# Altium schematic sheet Sheet2.SchDoc
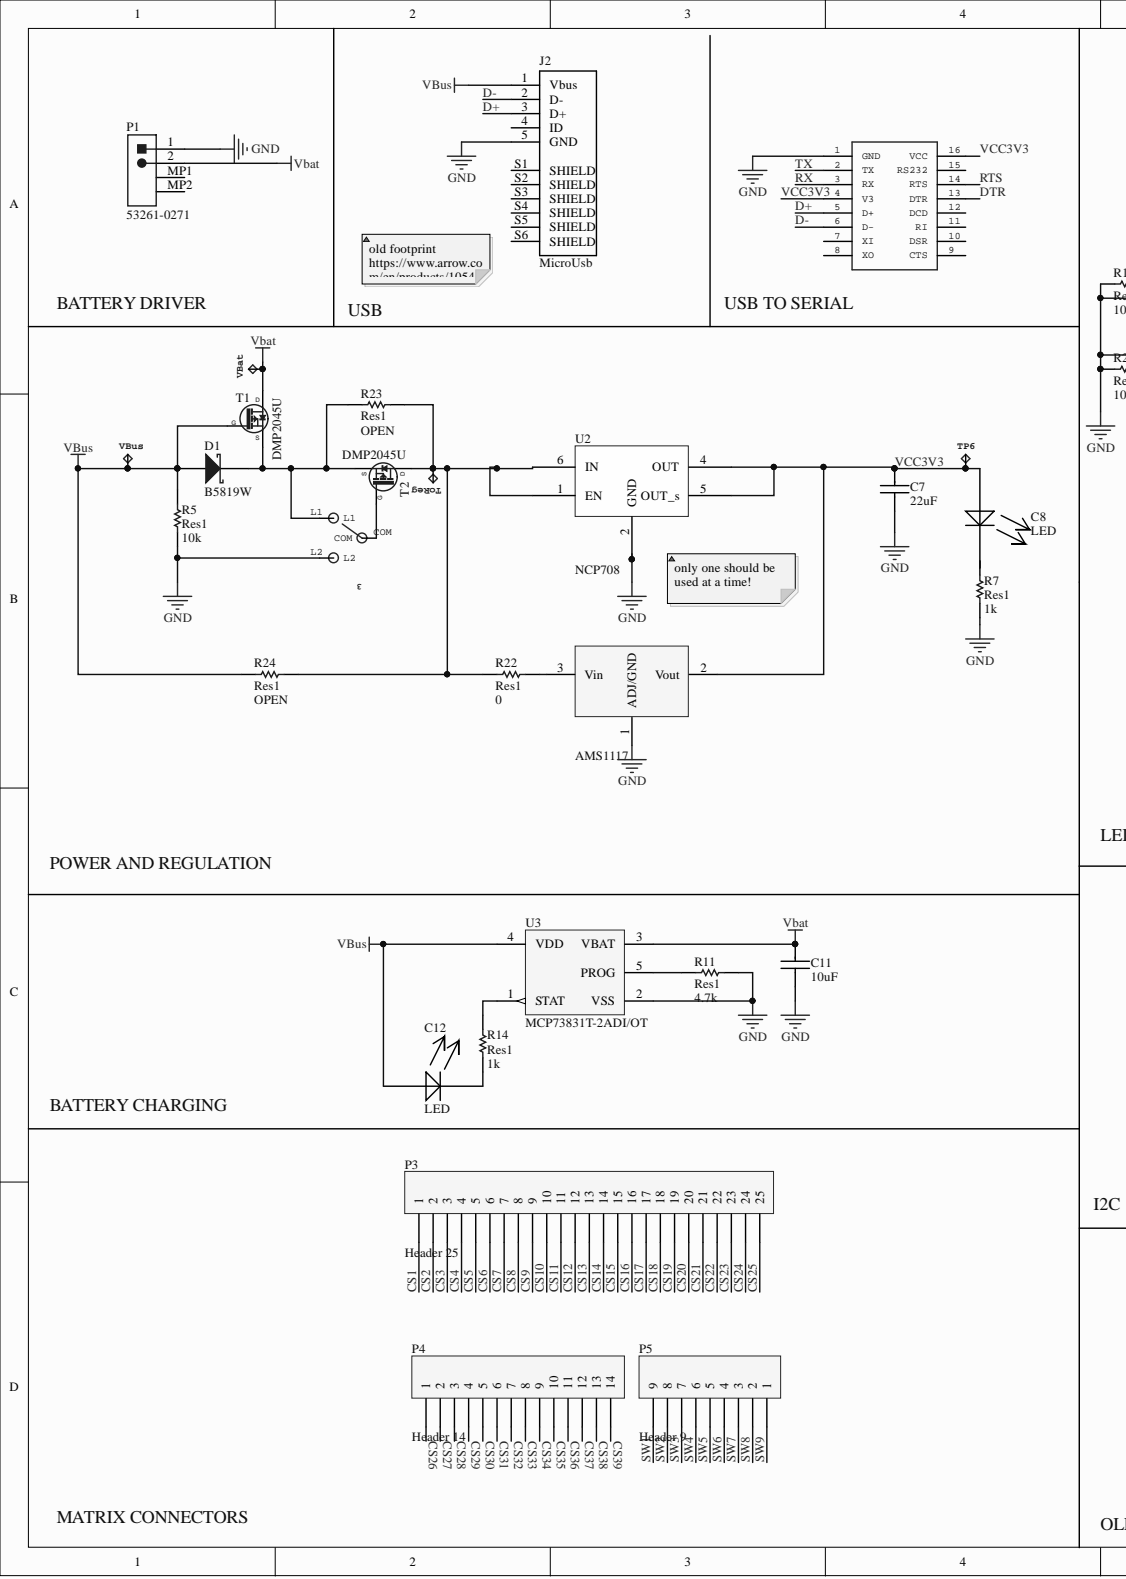
[diagram p0: Sheet2.SchDoc, left]
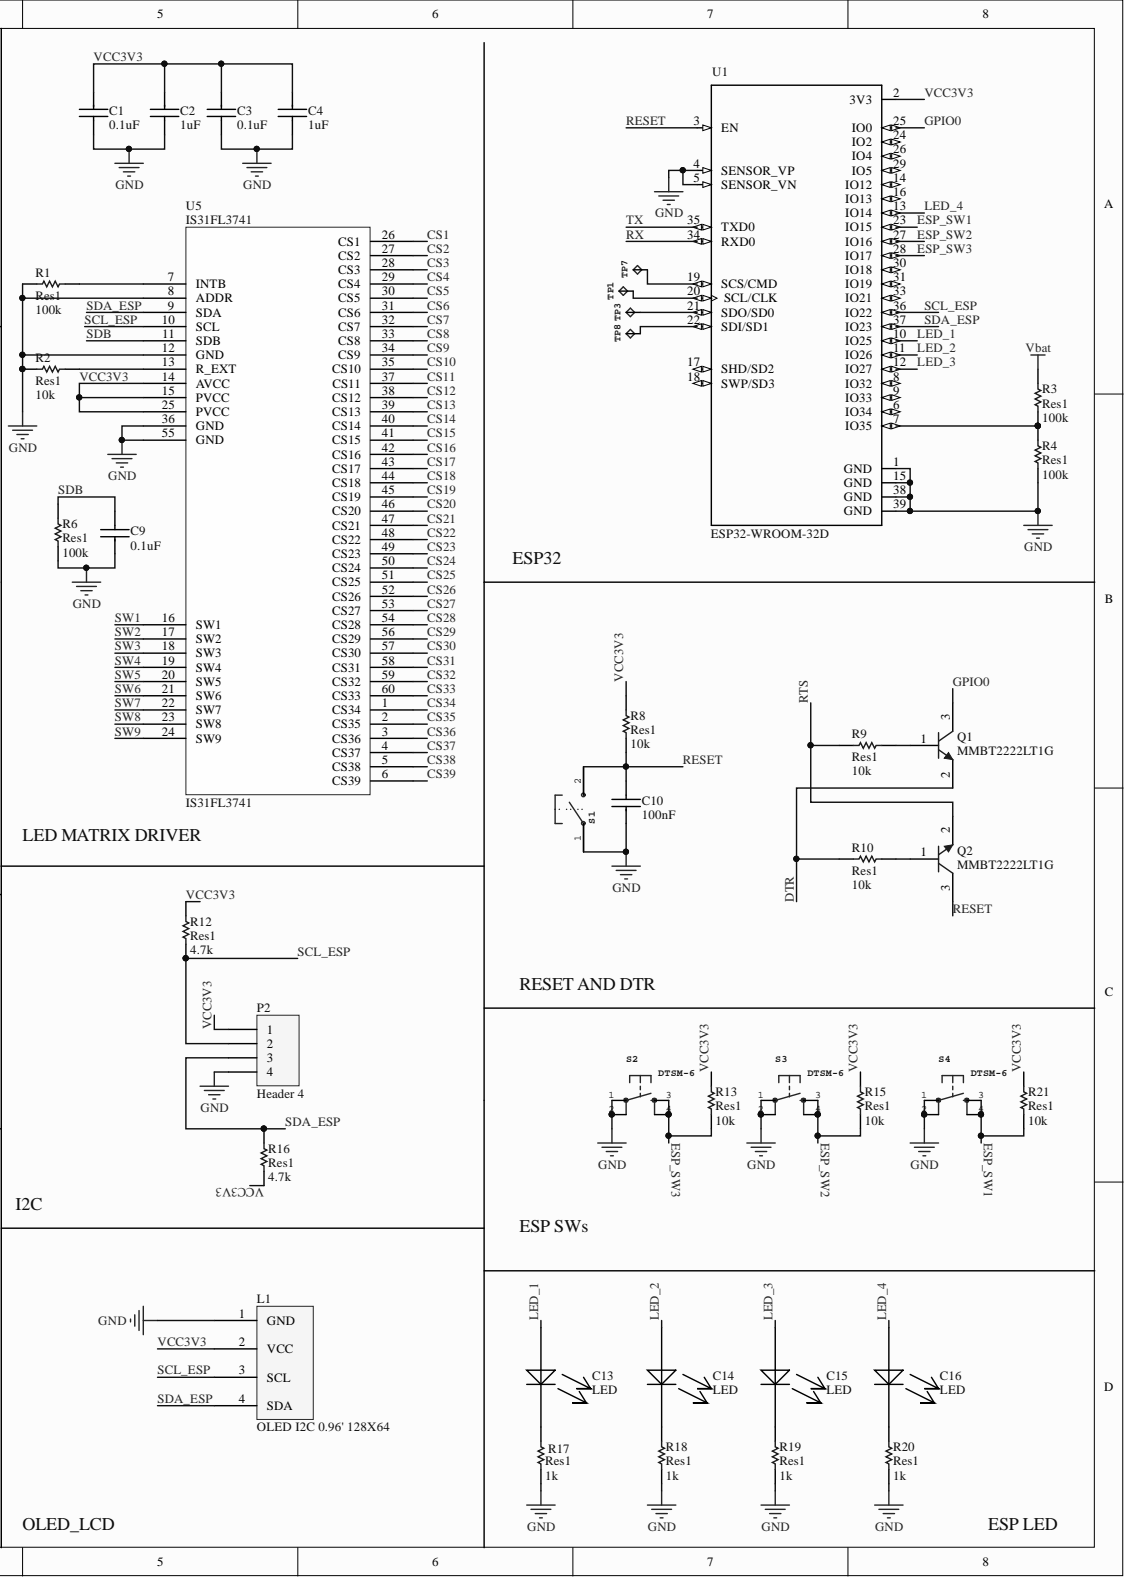
[diagram p1: Sheet2.SchDoc, right]

## Parts
3  Footprint of the VIMEX MSK-12C02 SPDT SMD Switch Created by mzst on 17-Jan-2016  [MSK-12C02]  (value not drawn)
AMS1117  AMS1117  [SOT-223-3]
C1  0.1uF  [C 0603 / 1608]
C2  1uF  [C 0603 / 1608]
C3  0.1uF  [C 0603 / 1608]
C4  1uF  [C 0603 / 1608]
C7  22uF  [C 0603 / 1608]
C8  LED  [LED 0603(2)]
C9  0.1uF  [C 0603 / 1608]
C10  100nF  [C 0603 / 1608]
C11  10uF  [C 0603 / 1608]
C12  LED  [LED 0603(2)]
C13  LED  [LED 0603(2)]
C14  LED  [LED 0603(2)]
C15  LED  [LED 0603(2)]
C16  LED  [LED 0603(2)]
D1  B5819W  [MCC-SOD123_V]
J2  MicroUsb  [FCI_10103594-0001LF_10103594-0001LF(Primary)]
L1  OLED I2C 0.96' 128X64  [OLED I2C 0.91]
P1  53261-0271  [MOLX-53261-0271_V]
P2  Header 4  [HDR1X4]
P3  Header 25  [HDR1X25]
P4  Header 14  [HDR1X14]
P5  Header 9  [HDR1X9]
Q1  MMBT2222LT1G  [ONSC-SOT-23-3-318-08_M]
Q2  MMBT2222LT1G  [ONSC-SOT-23-3-318-08_M]
R1  Res1  value 100k  [C 0603 / 1608]
R2  Res1  value 10k  [C 0603 / 1608]
R3  Res1  value 100k  [C 0603 / 1608]
R4  Res1  value 100k  [C 0603 / 1608]
R5  Res1  value 10k  [C 0603 / 1608]
R6  Res1  value 100k  [C 0603 / 1608]
R7  Res1  value 1k  [C 0603 / 1608]
R8  Res1  value 10k  [C 0603 / 1608]
R9  Res1  value 10k  [C 0603 / 1608]
R10  Res1  value 10k  [C 0603 / 1608]
R11  Res1  value 4.7k  [C 0603 / 1608]
R12  Res1  value 4.7k  [C 0603 / 1608]
R13  Res1  value 10k  [C 0603 / 1608]
R14  Res1  value 1k  [C 0603 / 1608]
R15  Res1  value 10k  [C 0603 / 1608]
R16  Res1  value 4.7k  [C 0603 / 1608]
R17  Res1  value 1k  [C 0603 / 1608]
R18  Res1  value 1k  [C 0603 / 1608]
R19  Res1  value 1k  [C 0603 / 1608]
R20  Res1  value 1k  [C 0603 / 1608]
R21  Res1  value 10k  [C 0603 / 1608]
R22  Res1  value 0  [C 0603 / 1608]
R23  Res1  value OPEN  [C 0603 / 1608]
R24  Res1  value OPEN  [C 0603 / 1608]
S1  Switch  [PUSH_SMD_3x4]  (value not drawn)
S2  DTSM-6  [DTSM-6]
S3  DTSM-6  [DTSM-6]
S4  DTSM-6  [DTSM-6]
T1  DMP2045U  [SOT95P237X112-3N]
T2  DMP2045U  [SOT95P237X112-3N]
ToReg  Test pad  [TP20R]  (value not drawn)
TP1  Test pad  [TP20R]  (value not drawn)
TP3  Test pad  [TP20R]  (value not drawn)
TP6  Test pad  [TP20R]  (value not drawn)
TP7  Test pad  [TP20R]  (value not drawn)
TP8  Test pad  [TP20R]  (value not drawn)
U1  ESP32-WROOM-32D  [MODULE_ESP32-WROOM-32D]
U2  NCP708  [UDFN6 CASE 517DD]
U3  MCP73831T-2ADI/OT  [SOT-23-OT5_M]
U4  CH340G  [SOIC16]  (value not drawn)
U5  IS31FL3741  [QFN40P700X700X80-61N]
VBat  Test pad  [TP20R]  (value not drawn)
VBus  Test pad  [TP20R]  (value not drawn)

## Nets
GND: 3.L2 AMS1117.1 C1.1 C2.1 C3.1 C4.1 C7.1 C9.2 C10.2 C11.1 J2.5(GND) L1.1(GND) P1.1 P2.4 R1.1 R2.1 R4.1 R5.2 R6.1 R7.2 R11.1 R17.2 R18.2 R19.2 R20.2 S1.1 S2.1 S2.2 S3.1 S3.2 S4.1 S4.2 U1.1(GND) U1.4(SENSOR_VP) U1.5(SENSOR_VN) U1.15(GND) U1.38(GND) U1.39(GND) U2.2(GND) U3.2(VSS) U4.1(GND) U5.8(ADDR) U5.12(GND) U5.36(GND) U5.55(GND)
Vbat: C11.2 P1.2 R3.2 T1.3 U3.3(VBAT) VBat.TP
VBus: C12.1 D1.1 J2.1(Vbus) R5.1 R24.1 T1.1 U3.4(VDD) VBus.TP
CS1: P3.1 U5.26(CS1)
CS2: P3.2 U5.27(CS2)
CS3: P3.3 U5.28(CS3)
CS4: P3.4 U5.29(CS4)
CS5: P3.5 U5.30(CS5)
CS6: P3.6 U5.31(CS6)
CS7: P3.7 U5.32(CS7)
CS8: P3.8 U5.33(CS8)
CS9: P3.9 U5.34(CS9)
CS10: P3.10 U5.35(CS10)
CS11: P3.11 U5.37(CS11)
CS12: P3.12 U5.38(CS12)
CS13: P3.13 U5.39(CS13)
CS14: P3.14 U5.40(CS14)
CS15: P3.15 U5.41(CS15)
CS16: P3.16 U5.42(CS16)
CS17: P3.17 U5.43(CS17)
CS18: P3.18 U5.44(CS18)
CS19: P3.19 U5.45(CS19)
CS20: P3.20 U5.46(CS20)
CS21: P3.21 U5.47(CS21)
CS22: P3.22 U5.48(CS22)
CS23: P3.23 U5.49(CS23)
CS24: P3.24 U5.50(CS24)
CS25: P3.25 U5.51(CS25)
CS26: P4.1 U5.52(CS26)
CS27: P4.2 U5.53(CS27)
CS28: P4.3 U5.54(CS28)
CS29: P4.4 U5.56(CS29)
CS30: P4.5 U5.57(CS30)
CS31: P4.6 U5.58(CS31)
CS32: P4.7 U5.59(CS32)
CS33: P4.8 U5.60(CS33)
CS34: P4.9 U5.1(CS34)
CS35: P4.10 U5.2(CS35)
CS36: P4.11 U5.3(CS36)
CS37: P4.12 U5.4(CS37)
CS38: P4.13 U5.5(CS38)
CS39: P4.14 U5.6(CS39)
D+: J2.3(D+) U4.5(D+)
D-: J2.2(D-) U4.6(D-)
DTR: Q1.2 R10.1 U4.13(DTR)
ESP_SW1: R21.1 S4.3 S4.4 U1.23(IO15)
ESP_SW2: R15.1 S3.3 S3.4 U1.27(IO16)
ESP_SW3: R13.1 S2.3 S2.4 U1.28(IO17)
GPIO0: Q1.3 U1.25(IO0)
LED_1: C13.1 U1.10(IO25)
LED_2: C14.1 U1.11(IO26)
LED_3: C15.1 U1.12(IO27)
LED_4: C16.1 U1.13(IO14)
RESET: C10.1 Q2.3 R8.2 S1.2 U1.3(EN)
RTS: Q2.2 R9.1 U4.14(RTS)
RX: U1.34(RXD0) U4.3(RX)
SCL_ESP: L1.3(SCL) P2.2 R12.2 U1.36(IO22) U5.10(SCL)
SDA_ESP: L1.4(SDA) P2.3 R16.2 U1.37(IO23) U5.9(SDA)
SDB: C9.1 R6.2 U5.11(SDB)
SW1: P5.9 U5.16(SW1)
SW2: P5.8 U5.17(SW2)
SW3: P5.7 U5.18(SW3)
SW4: P5.6 U5.19(SW4)
SW5: P5.5 U5.20(SW5)
SW6: P5.4 U5.21(SW6)
SW7: P5.3 U5.22(SW7)
SW8: P5.2 U5.23(SW8)
SW9: P5.1 U5.24(SW9)
TX: U1.35(TXD0) U4.2(TX)
VCC3V3: AMS1117.2 C1.2 C2.2 C3.2 C4.2 C7.2 C8.1 L1.2(VCC) P2.1 R8.1 R12.1 R13.2 R15.2 R16.1 R21.2 TP6.TP U1.2(3V3) U2.4(OUT) U2.5(OUT_s) U4.4(V3) U4.16(VCC) U5.14(AVCC) U5.15(PVCC) U5.25(PVCC)
Net3_COM: 3.COM T2.1
Net3_L1: 3.L1 D1.2 R23.1 T1.2 T2.2
NetAMS1117_3: AMS1117.3 R22.2
NetC8_2: C8.2 R7.1
NetC12_2: C12.2 R14.2
NetC13_2: C13.2 R17.1
NetC14_2: C14.2 R18.1
NetC15_2: C15.2 R19.1
NetC16_2: C16.2 R20.1
NetQ1_1: Q1.1 R9.2
NetQ2_1: Q2.1 R10.2
NetR1_2: R1.2 U5.7(INTB)
NetR2_2: R2.2 U5.13(R_EXT)
NetR3_1: R3.1 R4.2 U1.7(IO35)
NetR11_2: R11.2 U3.5(PROG)
NetR14_1: R14.1 U3.1(STAT)
NetR22_1: R22.1 R23.2 R24.2 T2.3 ToReg.TP U2.1(EN) U2.6(IN)
NetTP1_TP: TP1.TP U1.20(SCL/CLK)
NetTP3_TP: TP3.TP U1.21(SDO/SD0)
NetTP7_TP: TP7.TP U1.19(SCS/CMD)
NetTP8_TP: TP8.TP U1.22(SDI/SD1)

Not connected: J2 4(ID) S1(SHIELD) S2(SHIELD) S3(SHIELD) S4(SHIELD) S5(SHIELD) S6(SHIELD); P1 MP1 MP2; U1 6(IO34) 8(IO32) 9(IO33) 14(IO12) 16(IO13) 17(SHD/SD2) 18(SWP/SD3) 24(IO2) 26(IO4) 29(IO5) 30(IO18) 31(IO19) 33(IO21); U4 7(XI) 8(XO) 9(CTS) 10(DSR) 11(RI) 12(DCD) 15(RS232)

## Library data of the parts
3 = MSK-12C02_SMD_SPDT_SWITCH: Component Kind: Standard; Library Name: Switches.SchLib
AMS1117 = AMS1117: LDO 3.3V/5V/12V; Component Kind: Standard; Library Name: IC.SchLib
C1-C4 C7 C9-C11 = Capacitor: Component Kind: Standard; Library Name: Standard.SchLib
D1 = CMP-2100-03587-1: Schottky Barrier Rectifier, 1 A, 40 Vrm, -65 to 125 degC, 2-Pin SOD123, RoHS, Tape and Reel; Manufacturer: Micro Commercial Components; Component Kind: Standard; Datasheet URL: http://www.mccsemi.com/up_pdf/B5817W-B5819W(SOD-123).pdf; Datasheet Version: Rev. B, 01/2013; Library Name: Altium Content Vault; Manufacturer URL: http://www.mccsemi.com/; Package Description: 2-Pin Small Outline Diode, Body 2.7 x 1.6 mm, Height 1.35 mm; Package Reference: SOD123; Package URL: http://www.mccsemi.com/up_pdf/1N4448W(SOD-123).pdf
J2 = MicroUsb: 10103594-0001LF  Conn Micro USB Type B RCP 5 POS 0.65mm Solder RA SMD 5 Terminal 1 Port T/R; MF: Amphenol ICC; Availability: Unavailable; Component Kind: Standard; Library Name: Connectors.SchLib; MP: 10103594-0001LF; Package: None; Price: None; SnapEDA_Link: https://www.snapeda.com/parts/10103594-0001LF/Amphenol%20ICC/view-part/?ref=snap
P1 = CMP-2000-05633-1: PicoBlade(TM) Header, Right Angle, -40 to 85 degC, 2-Pin SMD, RoHS, Tape and Reel; Brand: Molex; Current - Max: 1A; Number of Positions: 2; Package Version: Rev. H, 11/2014; Pitch: 1.25mm; Voltage - Max: 125V; : 0532610271; Component Kind: Standard; Contact Material: PhosphorBronze; Contact Plating: Tin; Current Rating: 1A; Datasheet URL: http://www.molex.com/webdocs/datasheets/pdf/en-us/0532610271_PCB_HEADERS.pdf; Datasheet Version: 03/2016; Factory Pack Quantity: 1000; Housing Material: Thermoplastic; Library Name: Altium Content Vault; Manufacturer: Molex; Manufacturer URL: http://www.molex.com/; Mounting Angle: Right; Mounting Style: SMD/SMT; Mounting Technology: SurfaceMount; Number of Positions / Contacts: 2; Number of Rows: 1; Package Description: 2-Leads Header, Pitch 1.25 mm, Mated Height 3.4 mm; Package Reference: 53261-0271; Package URL: http://www.molex.com/pdm_docs/sd/532610271_sd.pdf; Packaging: Reel; Product Category: 1.25mmConnectors; Product Type: Headers; RoHS: Details; Series: 53261; Termination Style: SMD; Tradename: PicoBlade; Type: Shrouded; Voltage Rating: 125V
P2 = Header 4: Header, 4-Pin; Component Kind: Standard; LatestRevisionDate: 17-Jul-2002; LatestRevisionNote: Re-released for DXP Platform.; Library Name: Miscellaneous Connectors.IntLib; Signal Integrity: Connector; Publisher: Altium Limited
P3 = Header 25: Header, 25-Pin; Component Kind: Standard; LatestRevisionDate: 17-Jul-2002; LatestRevisionNote: Re-released for DXP Platform.; Library Name: Miscellaneous Connectors.IntLib; Signal Integrity: Connector; Publisher: Altium Limited
P4 = Header 14: Header, 14-Pin; Component Kind: Standard; LatestRevisionDate: 17-Jul-2002; LatestRevisionNote: Re-released for DXP Platform.; Library Name: Miscellaneous Connectors.IntLib; Signal Integrity: Connector; Publisher: Altium Limited
P5 = Header 9: Header, 9-Pin; Component Kind: Standard; LatestRevisionDate: 17-Jul-2002; LatestRevisionNote: Re-released for DXP Platform.; Library Name: Miscellaneous Connectors.IntLib; Signal Integrity: Connector; Publisher: Altium Limited
Q1 Q2 = CMP-0722-00001-1: General Purpose Transistor, NPN, 0.6 A IC Continuous, 3-Pin SOT23, RoHS, Tape and Reel; Manufacturer: ON Semiconductor; FT: 250 MHz; HFE Max.: 300; HFE Min.: 100; IC Continuous: 0.6 A; PTM Max.: 0.225 W; V(BR) CEO: 30 V Min.; Component Kind: Standard; ComponentLink1Description: Manufacturer URL; ComponentLink1URL: http://www.onsemi.com/; ComponentLink2Description: Datasheet; ComponentLink2URL: http://www.onsemi.com/pub_link/Collateral/MMBT2222LT1-D.PDF; ComponentLink3Description: Package Specification; ComponentLink3URL: http://www.onsemi.com/pub_link/Collateral/MMBT2222LT1-D.PDF; DatasheetVersion: Rev. 10; Library Name: Altium Content Vault; Mounting Technology: Surface Mount; PackageDescription: 3-Pin SOT23, Body 2.9 x 1.3 mm, Pitch 0.95 mm; PackageReference: SOT-23-3; PackageVersion: Rev. AP; Packing: Tape and Reel; PartNumber: MMBT2222LT1G; Polarity: NPN; RoHS: TRUE
R1-R24 = Res1: Resistor; Component Kind: Standard; LatestRevisionDate: 17-Jul-2002; LatestRevisionNote: Re-released for DXP Platform.; Library Name: Miscellaneous Devices.IntLib; PackageDescription: Axial Device, Thru-Hole; 2 Leads; 0.3 in Pin Spacing; PackageReference: AXIAL-0.3; Published: 8-Jun-2000; Signal Integrity: Res; Simulation: RESISTOR; Publisher: Altium Limited
S1 = B3U-1000P: MANUFACTURER: Omron; Component Kind: Standard; DIGIKEY: SW1020CT-ND; Library Name: Switches.SchLib; MPN: B3U-1000P
T1 T2 = Si2333CDS-T1-GE3: P-CHANNEL MOSFET WITH DIODE; Manufacturer: VISHAY SILICONIX; Part Description: -12V -5.1A P-Channel  TrenchFET® Power MOSFET; Bonding: No; Database Table Name: Transistors; PressFit: No; Case: SOT23-3; ComponentHeight: 1.12mm; Component Kind: Standard; Component Type: Standard; CreateDate: 03/03/2011; Device: Si2333CDS-T1-GE3; HelpURL: \\cern.ch\dfs\Applications\Altium\Datasheets\Si2333CDS-T1-GE3.pdf; LatestRevisionDate: 02/05/2011; Library Name: ICs And Semiconductors.DbLib; Manufacturer Part Number: Si2333CDS-T1-GE3; Mounted: Yes; PackageDescription: SOT, 0.95mm, pitch; 3 pin,1.30mm W X 2.92mm L X 1.12mm H body, IPC Medium Density; Part Number: Si2333CDS-T1-GE3; Pin Count: 3; Sense: No; SMD: Yes; Socket: No; Author: CERN DEM JLC
ToReg TP1 TP3 TP6-TP8 VBat VBus = TPTP20R: Component Kind: Standard; Library Name: Connectors.SchLib
U1 = ESP32-WROOM-32D: IC: SoC; GPIO, I2C, I2S, IR, SD, SDIO, SPI, UART; 2.7Ã·3.6VDC; 20dBm; MF: Espressif Systems; Availability: Warning; Component Kind: Standard; Library Name: Arduino.SchLib; MP: ESP32-WROOM-32D; Package: Module Espressif Systems; Price: 3.98 USD; SnapEDA_Link: https://www.snapeda.com/parts/ESP32-WROOM-32D/Espressif%20Systems/view-part/?ref=snap
U3 = CMP-0133-00142-1: Miniature Single-Cell, Fully Integrated Li-Ion, Li-Polymer Charge Management Controller, 4.2V, 5-Pin SOT-23, Industrial Temperature, Tape and Reel; Code_IPC: SOT23-5; Component Kind: Standard; DatasheetVersion: revE, Sep-2008; Library Name: Altium Content Vault; PackageDescription: 5-Lead Plastic Small Outline Transistor (OT) [SOT-23]; PackageReference: SOT-23-OT5; PackageVersion: revBB, Aug-2009
C8 C12-C16 = LED: Component Kind: Standard; Library Name: LEDs.SchLib
L1 = OLED I2C 0.96' 128X64: Component Kind: Standard; Library Name: LCDs.SchLib
S2-S4 = DTSM-6: Component Kind: Standard; Library Name: Switches.SchLib
U2 = NCP708: Component Kind: Standard; Library Name: IC.SchLib
U4 = CH340G: Component Kind: Standard; Library Name: IC.SchLib
U5 = IS31FL3741: Component Kind: Standard; Library Name: IC.SchLib
